# Altium schematic sheet HA_HiPower.SchDoc
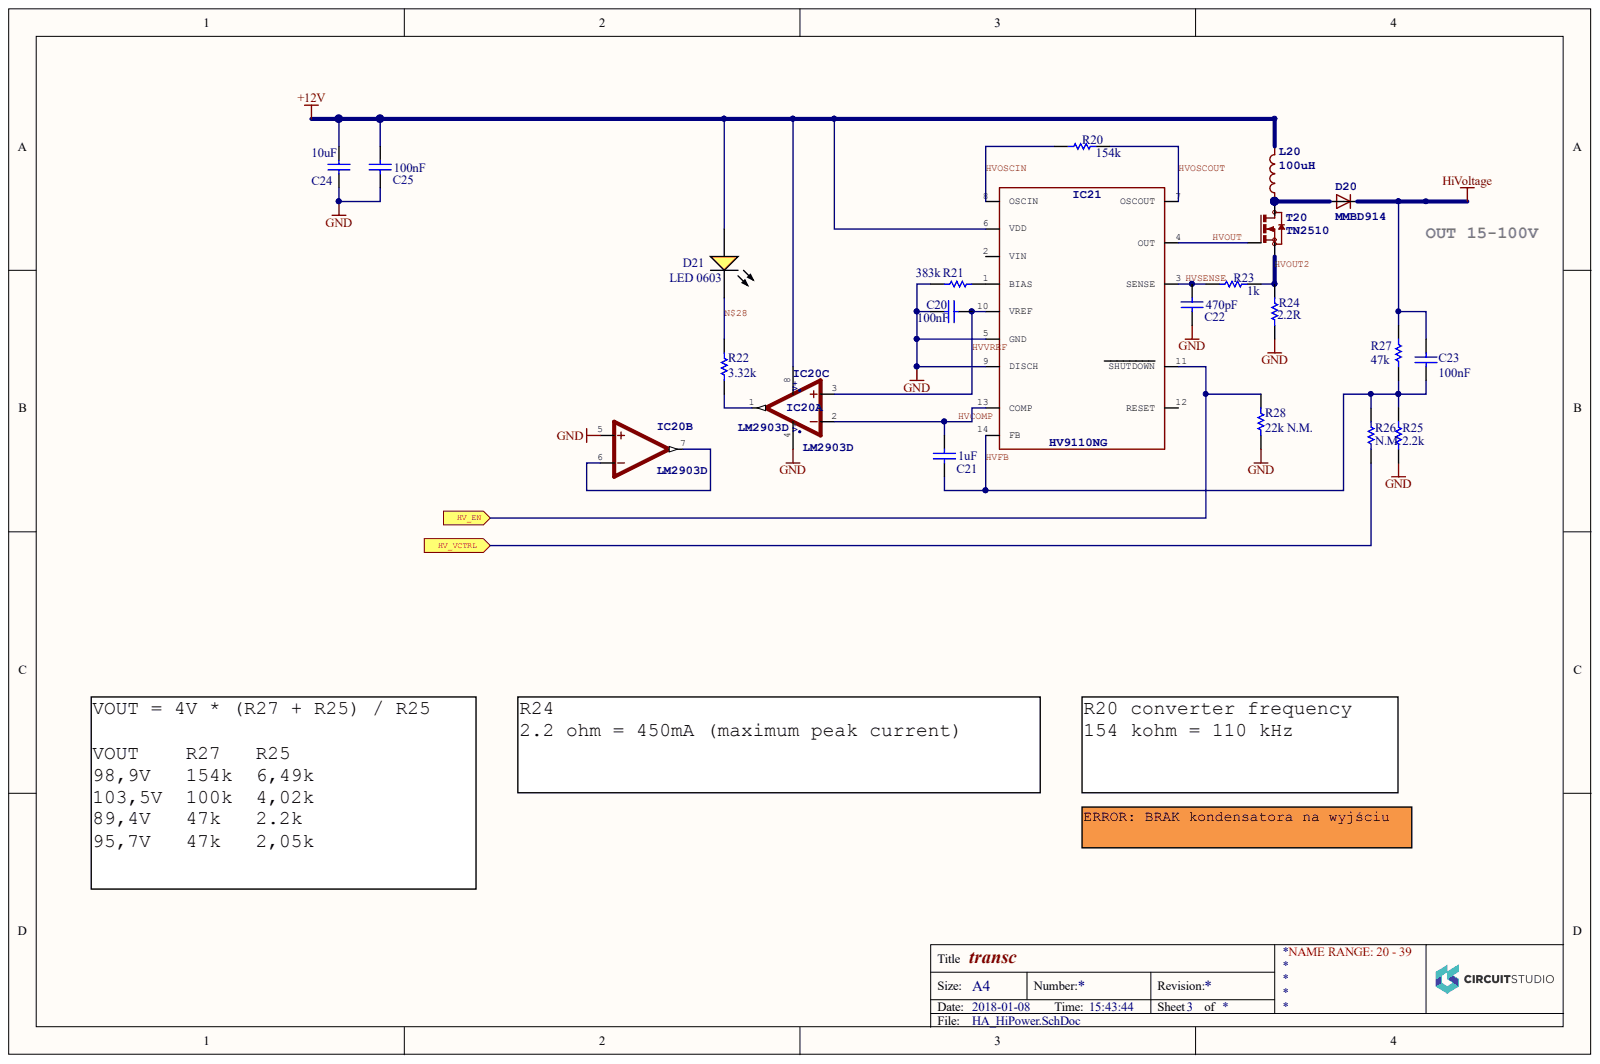
[diagram p0: HA_HiPower.SchDoc]

## Parts
C20  value 100nF  [CAPC0805(2012)145_L]  (hidden comment: CAP 100nF 100V 0805(2012))
C21  value 1uF  [CAPC0805(2012)145_L]  (hidden comment: 1uF 100V 0805(2012))
C22  value 470pF  [CAPC0805(2012)145_L]  (hidden comment: CAP 470pF 100V 0805(2012))
C23  value 100nF  [CAPC0805(2012)145_L]  (hidden comment: CAP 100nF 100V 0805(2012))
C24  value 10uF  [CAPC1206(3216)190_N]  (hidden comment: CAP 10uF 35V 1206(3216))
C25  value 100nF  [CAPC0805(2012)145_L]  (hidden comment: CAP 100nF 100V 0805(2012))
D20  MMBD914  [SOT23]
D21  LED 0603  Case\Package: 0603  [LTST-C190KSKT_V]
IC20  LM2903D  [SO08]  (parts A,B,C)
IC21  HV9110NG  [SOIC14]
L20  100uH  [LPS8045B]
R20  154k  [RESC0603(1608)_N]
R21  383k  [RESC0603(1608)_N]
R22  3.32k  [RESC0603(1608)_N]
R23  1k  [RESC0603(1608)_N]
R24  value 2.2R  [RESC2512(6432)_M]  (hidden comment: 2.2R 5% 2512)
R25  2.2k  [RESC0603(1608)_N]
R26  N.M.  [RESC0603(1608)_N]
R27  47k  [RESC0603(1608)_N]
R28  22k N.M.  [RESC0603(1608)_N]
T20  TN2510  [SOT89]

## Ports
HV_EN, HV_VCTRL

## Nets
+12V: C24.2 C25.2 D21.1 IC20.8 IC21.6(VDD) L20.2
GND: C20.2 C22.1 C24.1 C25.1 IC20.4 IC20.5 IC21.5(GND) IC21.9(DISCH) R21.1 R24.1 R25.1 R28.1
HIVOLTAGE: C23.1 D20.C R27.2
HV_EN: IC21.11(SHUTDOWN) R28.2 ; port HV_EN
HV_VCTRL: R26.1 ; port HV_VCTRL
HVCOMP: C21.2 IC20.2 IC21.13(COMP)
HVFB: C21.1 C23.2 IC21.14(FB) R25.2 R26.2 R27.1
HVOSCIN: IC21.8(OSCIN) R20.1
HVOSCOUT: IC21.7(OSCOUT) R20.2
HVOUT: IC21.4(OUT) T20.1
HVOUT2: R23.2 R24.2 T20.3
HVSENSE: C22.2 IC21.3(SENSE) R23.1
HVVREF: C20.1 IC20.3 IC21.10(VREF)
N$28: D21.2 R22.1
NetD20_B: D20.B L20.1 T20.2
NetIC20_1: IC20.1 R22.2
NetIC20_6: IC20.6 IC20.7
NetIC21_1: IC21.1(BIAS) R21.2

Not connected: IC21 2(VIN) 12(RESET)

## Library data of the parts
C20-C23 C25 = CMP-1036-04431-1: Case-EIA: 0805; Case-Metric: 2012; Tolerance: Â±20%; Component Kind: Standard; Library Name: Altium Content Vault; Max Thickness: 1.45 mm; Rated Voltage: 100 V; Technology: SMT
C24 = CMP-1037-04990-1: CAP 1206 (3216 Metric) Thickness 1.9mm SMD; Case-EIA: 1206; Case-Metric: 3216; Rated Voltage: 10 V; Tolerance: Â±20%; Component Kind: Standard; Library Name: Altium Content Vault; Max Thickness: 1.9 mm; Technology: SMT
D20 = DIODE-SOT23: Component Kind: Standard; Library Name: _toan_elem.SchLib
D21 = CMP-1674-00009-1: Power Rating: 100 mW; Resistance: 12 kohm; Tolerance: 1%; Voltage Rating: 50 V; Case Code (Imperial): 0603; Case Code (Metric): 1608; Component Kind: Standard; ComponentLink1Description: Manufacturer URL; ComponentLink1URL: http://www.us.liteon.com/; ComponentLink2Description: Datasheet; ComponentLink2URL: http://optoelectronics.liteon.com/upload/download/DS-22-99-0189/S_110_LTST-C190KSKT.pdf; DatasheetVersion: Rev. I, 10/2012; Height: 0.45 mm; Length: 1.6 mm; Library Name: Altium Content Vault; Max Operating Temperature: 155 degC; Min Operating Temperature: -55 degC; Mount: Surface Mount; PackageDescription: 2-Pin Surface Mount Device, Body 1.6 x 0.8 mm; Package Quantity: 5000; PackageReference: C190KSKT; Packaging: Tape and Reel; Pins: 2; REACH SVHC: No SVHC; RoHSCompliant: true; Voltage Rating (DC): 50 V; Width: 0.8 mm
IC20 = LM2903D: COMPARATOR; Component Kind: Standard; Library Name: uw.SchLib
L20 = LDE1205: MPN: LPS8045B-104; Component Kind: Standard; Inductance: 100uH; Library Name: _toan_elem.SchLib
R20 R27 R28 = CMP-1012-00122-1: 1% 0603 SMD; Value: 154k Ohm; Component Kind: Standard; Library Name: Altium Content Vault; Tolerance: 1 %
R21 = CMP-1012-00122-1: 1% 0603 SMD; Value: 383k Ohm; Component Kind: Standard; Library Name: Altium Content Vault; Tolerance: 1 %
R22 = CMP-1012-00122-1: 1% 0603 SMD; Value: 3.32k Ohm; Component Kind: Standard; Library Name: Altium Content Vault; Tolerance: 1 %
R23 = CMP-1012-00122-1: 1% 0603 SMD; Value: 1k Ohm; Component Kind: Standard; Library Name: Altium Content Vault; Tolerance: 1 %
R24 = CMP-1018-00050-1: 2.2R 1W 5% 2512 SMD; Case-EIA: 2512; Case-Metric: 6432; Component Kind: Standard; Library Name: Altium Content Vault; Power: 1 W; Technology: SMT; Tolerance: 5 %
R25 = CMP-1012-00122-1: 1% 0603 SMD; Value: 6.49k Ohm; Component Kind: Standard; Library Name: Altium Content Vault; Tolerance: 1 %
R26 = CMP-1012-00122-1: 1% 0603 SMD; Value: k Ohm; Component Kind: Standard; Library Name: Altium Content Vault; Tolerance: 1 %
T20 = MOSFET_NSOT89: Component Kind: Standard; Library Name: uw.SchLib
IC21 = HV9110NG: Component Kind: Standard; Library Name: microchip.SchLib
